# Altium schematic sheet Relay.SchDoc
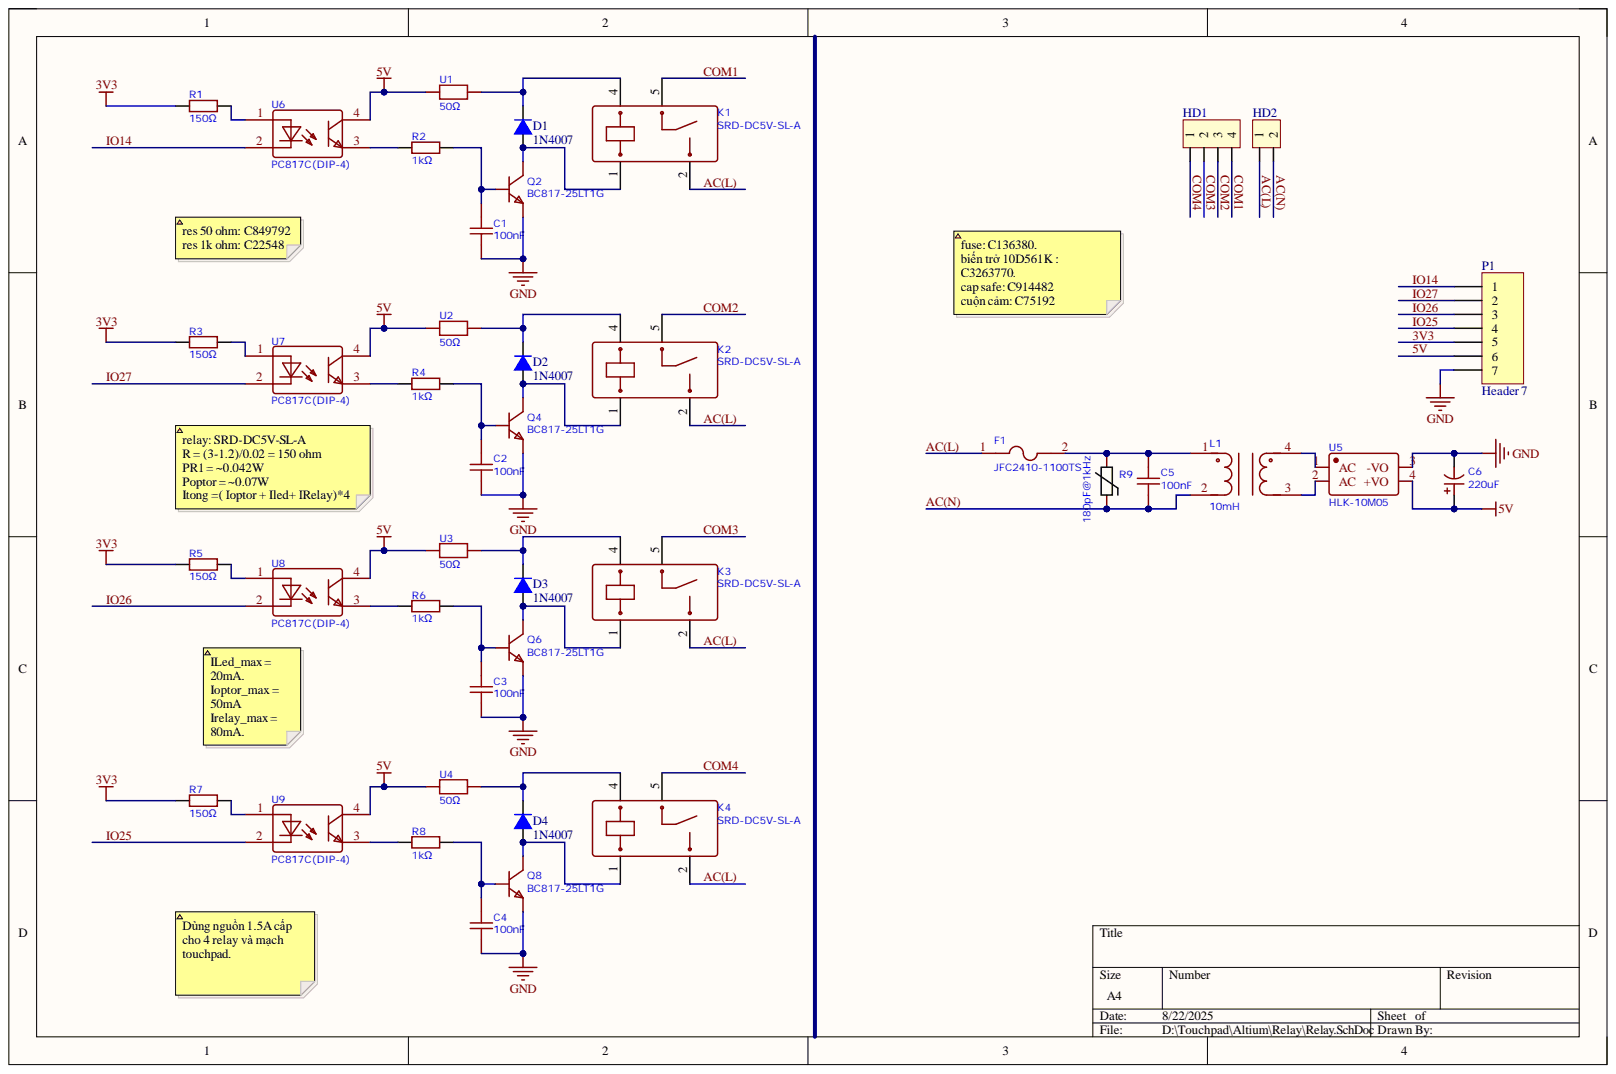
[diagram p0: Relay.SchDoc]

## Parts
C1  100nF  [C0603]
C2  100nF  [C0603]
C3  100nF  [C0603]
C4  100nF  [C0603]
C5  100nF  [CAP-TH_L10.0-W5.0-P7.50-D0.6]
C6  220uF  [CAP-SMD_BD6.3-L6.6-W6.6-FD]
D1  1N4007  [D1A]
D2  1N4007  [D1A]
D3  1N4007  [D1A]
D4  1N4007  [D1A]
F1  JFC2410-1100TS  [F2410]
HD1  (no value)  [HED4]
HD2  (no value)  [HED2]
K1  SRD-DC5V-SL-A  [RELAY-TH_SRD-DCXXV-SL-A]
K2  SRD-DC5V-SL-A  [RELAY-TH_SRD-DCXXV-SL-A]
K3  SRD-DC5V-SL-A  [RELAY-TH_SRD-DCXXV-SL-A]
K4  SRD-DC5V-SL-A  [RELAY-TH_SRD-DCXXV-SL-A]
L1  10mH  [FILTER-TH_UU9.8Y-10MH]
P1  Header 7  [HDR1X7]
Q2  BC817-25LT1G  [SOT-23-3_L2.9-W1.6-P1.90-LS2.8-BR]
Q4  BC817-25LT1G  [SOT-23-3_L2.9-W1.6-P1.90-LS2.8-BR]
Q6  BC817-25LT1G  [SOT-23-3_L2.9-W1.6-P1.90-LS2.8-BR]
Q8  BC817-25LT1G  [SOT-23-3_L2.9-W1.6-P1.90-LS2.8-BR]
R1  150Ω  [R0603]
R2  1kΩ  [R0603]
R3  150Ω  [R0603]
R4  1kΩ  [R0603]
R5  150Ω  [R0603]
R6  1kΩ  [R0603]
R7  150Ω  [R0603]
R8  1kΩ  [R0603]
R9  180pF@1kHz  [RES-TH_L12.5-W5.9-P7.50-D0.8-S3.00]
U1  50Ω  [R0603]
U2  50Ω  [R0603]
U3  50Ω  [R0603]
U4  50Ω  [R0603]
U5  HLK-10M05  [PWRM-TH_HLK-10M05]
U6  PC817C(DIP-4)  [DIP-4_L4.6-W6.5-P2.54-LS7.6-BL]
U7  PC817C(DIP-4)  [DIP-4_L4.6-W6.5-P2.54-LS7.6-BL]
U8  PC817C(DIP-4)  [DIP-4_L4.6-W6.5-P2.54-LS7.6-BL]
U9  PC817C(DIP-4)  [DIP-4_L4.6-W6.5-P2.54-LS7.6-BL]

## Nets
GND: C1.1 C2.1 C3.1 C4.1 C6.2 P1.7 Q2.2 Q4.2 Q6.2 Q8.2 U5.3(-VO)
3V3: P1.5 R1.1 R3.1 R5.1 R7.1
5V: C6.1 P1.6 U1.1 U2.1 U3.1 U4.1 U5.4(+VO) U6.4 U7.4 U8.4 U9.4
AC(L): F1.1 HD2.1 K1.2 K2.2 K3.2 K4.2
AC(N): C5.1 HD2.2 L1.2 R9.1
COM1: HD1.4 K1.5
COM2: HD1.3 K2.5
COM3: HD1.2 K3.5
COM4: HD1.1 K4.5
IO14: P1.1 U6.2
IO25: P1.4 U9.2
IO26: P1.3 U8.2
IO27: P1.2 U7.2
NetC1_2: C1.2 Q2.1 R2.2
NetC2_2: C2.2 Q4.1 R4.2
NetC3_2: C3.2 Q6.1 R6.2
NetC4_2: C4.2 Q8.1 R8.2
NetC5_2: C5.2 F1.2 L1.1 R9.2
NetD1_1: D1.1 K1.1 Q2.3
NetD1_2: D1.2 K1.4 U1.2
NetD2_1: D2.1 K2.1 Q4.3
NetD2_2: D2.2 K2.4 U2.2
NetD3_1: D3.1 K3.1 Q6.3
NetD3_2: D3.2 K3.4 U3.2
NetD4_1: D4.1 K4.1 Q8.3
NetD4_2: D4.2 K4.4 U4.2
NetL1_3: L1.3 U5.2(AC)
NetL1_4: L1.4 U5.1(AC)
NetR1_2: R1.2 U6.1
NetR2_1: R2.1 U6.3
NetR3_2: R3.2 U7.1
NetR4_1: R4.1 U7.3
NetR5_2: R5.2 U8.1
NetR6_1: R6.1 U8.3
NetR7_2: R7.2 U9.1
NetR8_1: R8.1 U9.3

## Library data of the parts
C1-C4 = CGA0603X7R104K100JT: Component Kind: Standard; Library Name: 0603-0.1uf-10V.SchLib
C5 = X2-104K-275VAC: Component Kind: Standard; Library Name: capSafe-0.1uf-275Vac.SchLib
C6 = RVT220UF10V67RV0037: Component Kind: Standard; Library Name: cap220uF-10V.SchLib
D1-D4 = D1A: Diode 1A; Component Kind: Standard; Value: 1N4007; Library Name: syhaunguyen.SchLib
HD1 = Hed4: Header 4 (Green); Component Kind: Standard; Library Name: Library AL.IntLib; Value: Header 4
HD2 = Hed2: Header 2 (Green); Component Kind: Standard; Library Name: Library AL.IntLib; Value: Header 2
L1 = UU9.8Y-10mH: Component Kind: Standard; Library Name: intructor-10mH-0.5A.SchLib
P1 = Header 7: Header, 7-Pin; Component Kind: Standard; LatestRevisionDate: 17-Jul-2002; LatestRevisionNote: Re-released for DXP Platform.; Library Name: Library AL.IntLib; Publisher: Altium Limited
R1 R3 R5 R7 = RC0603FR-07100RL: Component Kind: Standard; Library Name: res100ohm-0.1W.SchLib
R2 R4 R6 R8 = RC0603FR-071KL: Component Kind: Standard; Library Name: 0603-1kohm.SchLib
R9 = MOV-10D561K: Component Kind: Standard; Library Name: 10D561k.SchLib
U1-U4 = RT0603BRE0750RL: Component Kind: Standard; Library Name: 0603-50ohm-0.1W.SchLib
F1 = JFC2410-1100TS: Component Kind: Standard; Library Name: fuse-1A-250V.SchLib
K1-K4 = SRD-DC5V-SL-A: Component Kind: Standard; Library Name: relay-250VAC-5V.SchLib
Q2 Q4 Q6 Q8 = BC817-25LT1G: Component Kind: Standard; Library Name: pc817.SchLib
U5 = HLK-10M05: Component Kind: Standard; Library Name: hlk-5V-10W-2A.SchLib
U6-U9 = PC817C(DIP-4): Component Kind: Standard; Library Name: pc817c-dip.SchLib
